# Altium schematic sheet Microcontroller_CC1350.SchDoc
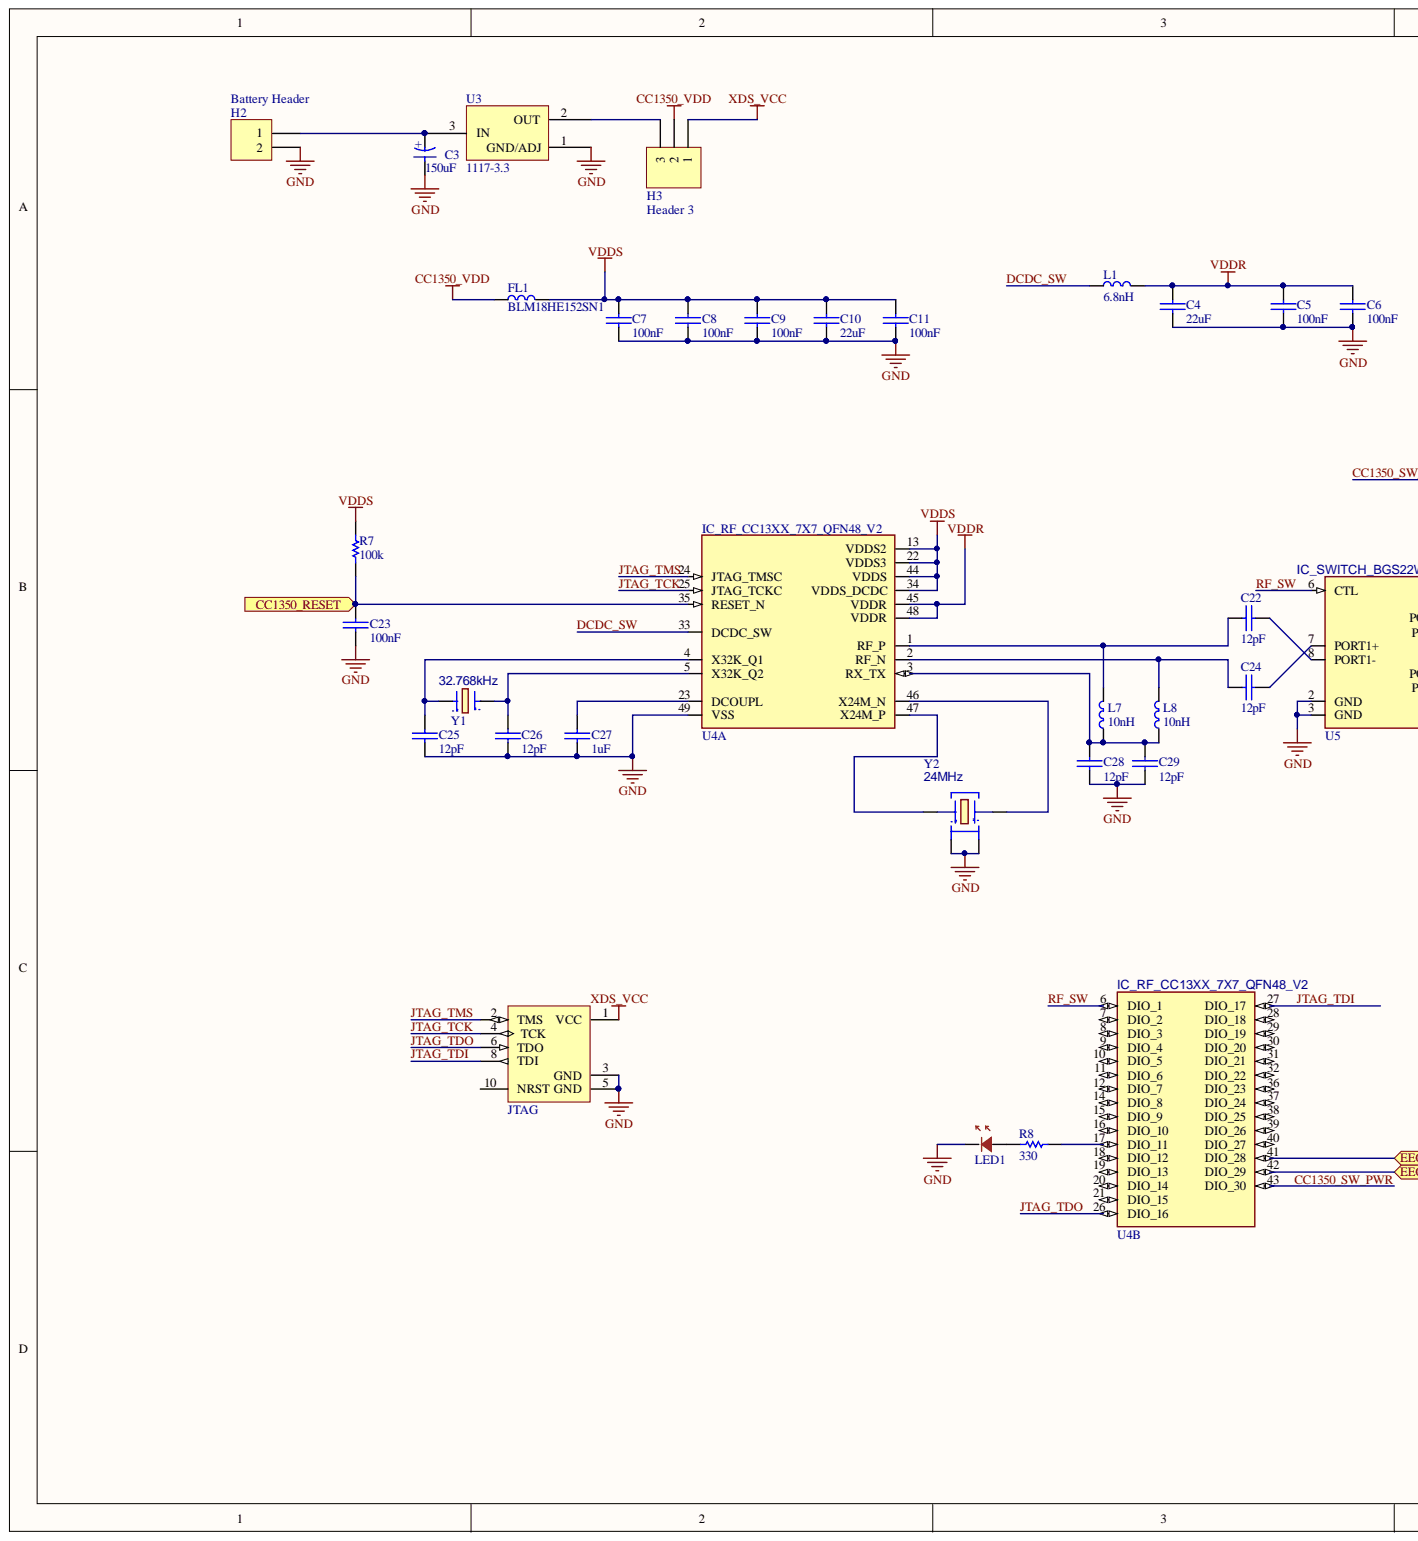
[diagram p0: Microcontroller_CC1350.SchDoc, left]
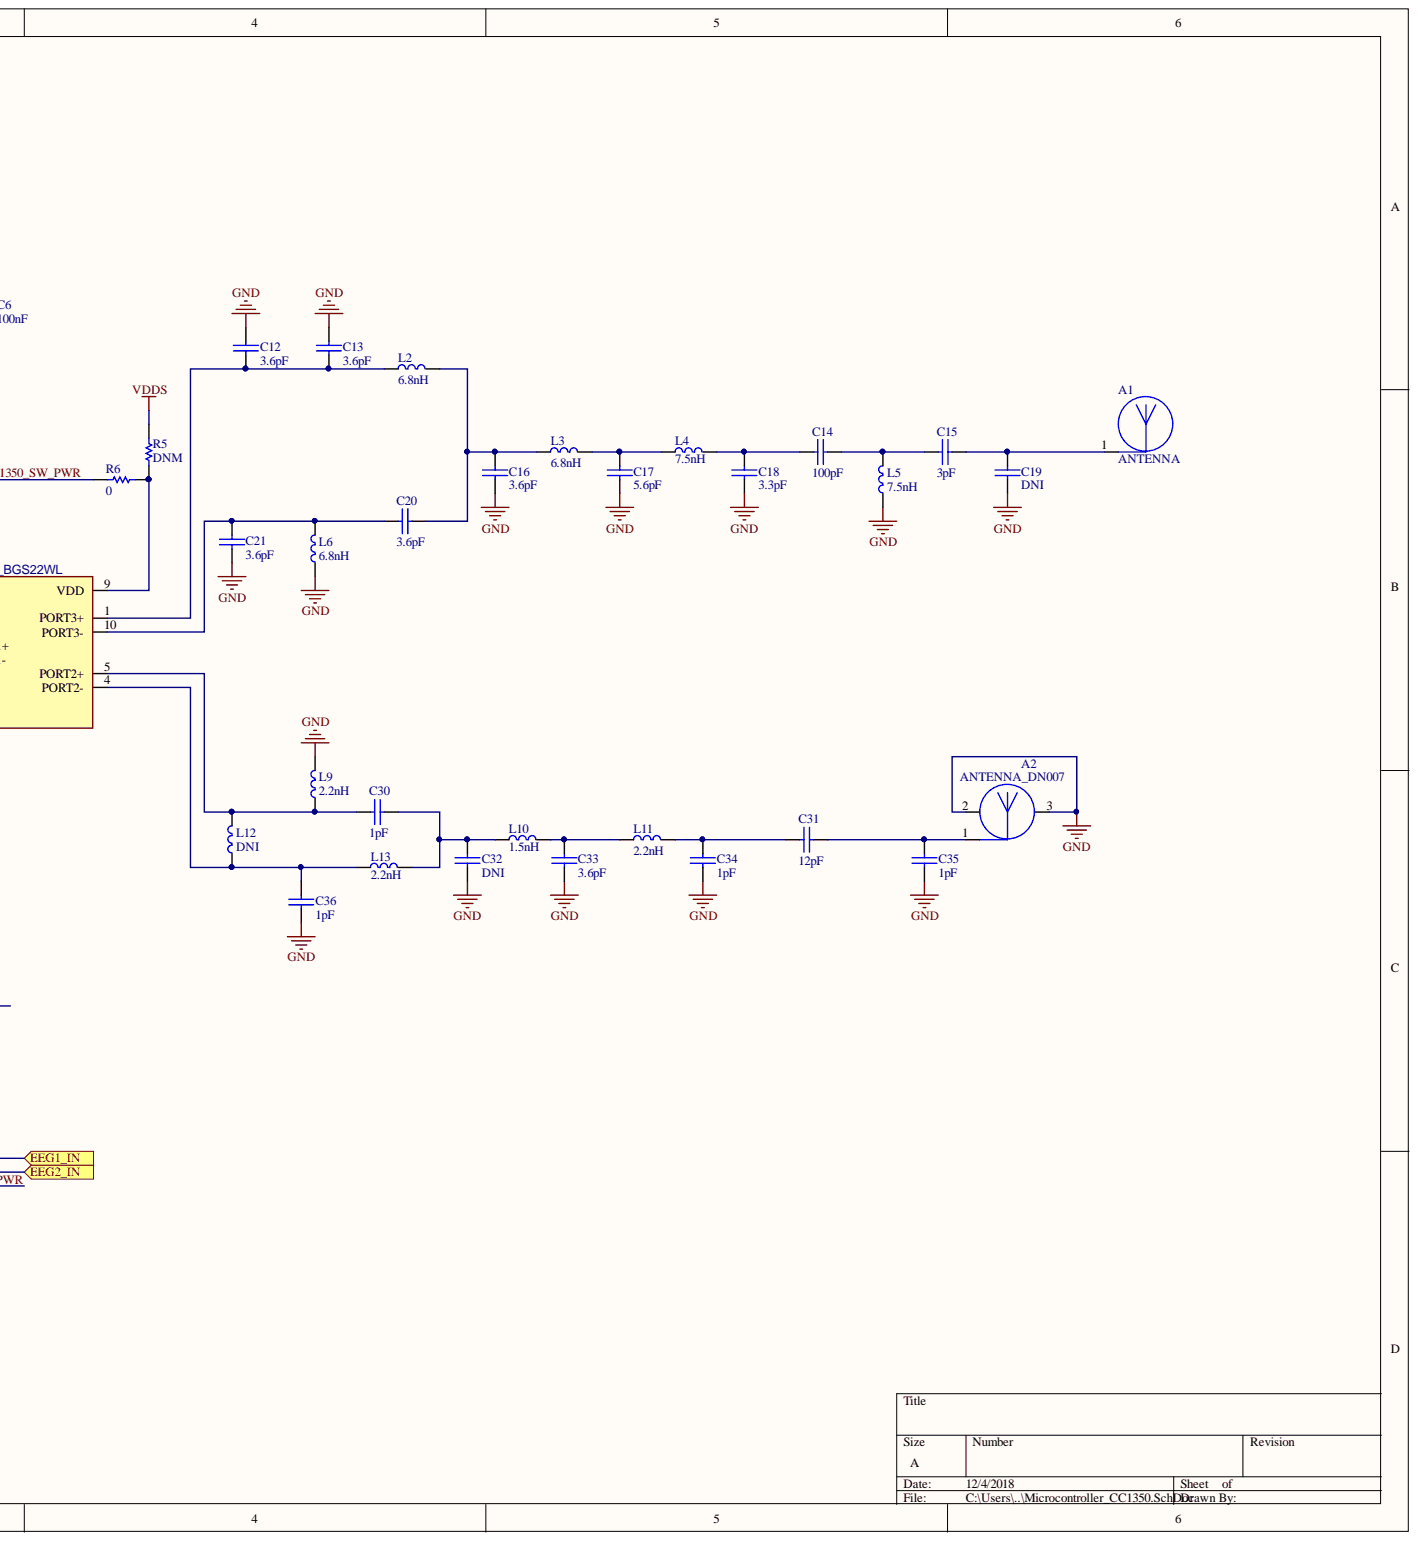
[diagram p1: Microcontroller_CC1350.SchDoc, right]

## Parts
A1  ANTENNA  [Sub-1GHz-Antenna]
A2  ANTENNA_DN007  [Inverted_F_Antenna]
C3  150uF  [2312 [IMP] Polar]
C4  22uF  [0603[IMP]]
C5  100nF  [0402[IMP]]
C6  100nF  [0402[IMP]]
C7  100nF  [0402[IMP]]
C8  100nF  [0402[IMP]]
C9  100nF  [0402[IMP]]
C10  22uF  [0603[IMP]]
C11  100nF  [0402[IMP]]
C12  3.6pF  [0402[IMP]]
C13  3.6pF  [0402[IMP]]
C14  100pF  [0402[IMP]]
C15  3pF  [0402[IMP]]
C16  3.6pF  [0402[IMP]]
C17  5.6pF  [0402[IMP]]
C18  3.3pF  [0402[IMP]]
C19  DNI  [0402[IMP]]
C20  3.6pF  [0402[IMP]]
C21  3.6pF  [0402[IMP]]
C22  12pF  [0402[IMP]]
C23  100nF  [0402[IMP]]
C24  12pF  [0402[IMP]]
C25  12pF  [0402[IMP]]
C26  12pF  [0402[IMP]]
C27  1uF  [0402[IMP]]
C28  12pF  [0402[IMP]]
C29  12pF  [0402[IMP]]
C30  1pF  [0402[IMP]]
C31  12pF  [0402[IMP]]
C32  DNI  [0402[IMP]]
C33  3.6pF  [0402[IMP]]
C34  1pF  [0402[IMP]]
C35  1pF  [0402[IMP]]
C36  1pF  [0402[IMP]]
FL1  BLM18HE152SN1  [0603[IMP]]
H2  Battery Header  [S2B-PH-SM4-TB]
H3  Header 3  [HDR1X3]
H4  JTAG  [HDR-2*5-0.05_Without_Through_Hole]
L1  6.8nH  [0402[IMP]]
L2  6.8nH  [0402[IMP]]
L3  6.8nH  [0402[IMP]]
L4  7.5nH  [0402[IMP]]
L5  7.5nH  [0402[IMP]]
L6  6.8nH  [0402[IMP]]
L7  10nH  [0402[IMP]]
L8  10nH  [0402[IMP]]
L9  2.2nH  [0402[IMP]]
L10  1.5nH  [0402[IMP]]
L11  2.2nH  [0402[IMP]]
L12  DNI  [0402[IMP]]
L13  2.2nH  [0402[IMP]]
LED1  LEDR  [0805[IMP]]  (value not drawn)
R5  DNM  [0402[IMP]]
R6  0  [0402[IMP]]
R7  100k  [0402[IMP]]
R8  330  [0402[IMP]]
U3  1117-3.3  [SOT-223]
U4  IC_RF_CC13XX_7X7_QFN48_V2  [RGZ(S-PVQFN-N48) - duplicate]  (parts A,B)
U5  IC_SWITCH_BGS22WL  [TSLP-10-1]
Y1  32.768kHz  [FC-135]
Y2  24MHz  [TSX-3225]

## Ports
CC1350_RESET, EEG1_IN, EEG2_IN

## Nets
CC1350_VDD: FL1.1 H3.2
GND: A2.2 A2.3 C3.1 C4.2 C5.2 C6.2 C7.2 C8.2 C9.2 C10.2 C11.2 C12.1 C13.1 C16.2 C17.2 C18.2 C19.1 C21.2 C23.2 C25.2 C26.2 C27.2 C28.2 C29.2 C32.1 C33.2 C34.1 C35.1 C36.2 H2.2 H4.3(GND) H4.5(GND) L5.1 L6.1 L9.2 LED1.2 U3.1 U4.49(VSS) U5.2(GND) U5.3(GND) Y2.2 Y2.4
VDDR: C4.1 C5.1 C6.1 L1.2 U4.45(VDDR) U4.48(VDDR)
VDDS: C7.1 C8.1 C9.1 C10.1 C11.1 FL1.2 R5.2 R7.2 U4.13(VDDS2) U4.22(VDDS3) U4.34(VDDS_DCDC) U4.44(VDDS)
XDS_VCC: H3.1 H4.1(VCC)
CC1350_RESET: C23.1 R7.1 U4.35(RESET_N) ; port CC1350_RESET
CC1350_SW_PWR: R6.2 U4.43(DIO_30)
DCDC_SW: L1.1 U4.33(DCDC_SW)
EEG1_IN: U4.41(DIO_28) ; port EEG1_IN
EEG2_IN: U4.42(DIO_29) ; port EEG2_IN
JTAG_TCK: H4.4(TCK) U4.25(JTAG_TCKC)
JTAG_TDI: H4.8(TDI) U4.27(DIO_17)
JTAG_TDO: H4.6(TDO) U4.26(DIO_16)
JTAG_TMS: H4.2(TMS) U4.24(JTAG_TMSC)
RF_SW: U4.6(DIO_1) U5.6(CTL)
NetA1_1: A1.1 C15.2 C19.2
NetA2_1: A2.1 C31.2 C35.2
NetC3_2: C3.2 H2.1 U3.3
NetC12_2: C12.2 C13.2 L2.1 U5.1(PORT3+)
NetC14_1: C14.1 C18.1 L4.2
NetC14_2: C14.2 C15.1 L5.2
NetC16_1: C16.1 C20.2 L2.2 L3.1
NetC17_1: C17.1 L3.2 L4.1
NetC20_1: C20.1 C21.1 L6.2 U5.10(PORT3-)
NetC22_1: C22.1 L7.2 U4.1(RF_P)
NetC22_2: C22.2 U5.8(PORT1-)
NetC24_1: C24.1 L8.2 U4.2(RF_N)
NetC24_2: C24.2 U5.7(PORT1+)
NetC25_1: C25.1 U4.4(X32K_Q1) Y1.1
NetC26_1: C26.1 U4.5(X32K_Q2) Y1.2
NetC27_1: C27.1 U4.23(DCOUPL)
NetC28_1: C28.1 C29.1 L7.1 L8.1 U4.3(RX_TX)
NetC30_1: C30.1 L9.1 L12.2 U5.5(PORT2+)
NetC30_2: C30.2 C32.2 L10.1 L13.2
NetC31_1: C31.1 C34.2 L11.2
NetC33_1: C33.1 L10.2 L11.1
NetC36_1: C36.1 L12.1 L13.1 U5.4(PORT2-)
NetH3_3: H3.3 U3.2
NetLED1_1: LED1.1 R8.1
NetR5_1: R5.1 R6.1 U5.9(VDD)
NetR8_2: R8.2 U4.17(DIO_11)
NetU4_46: U4.46(X24M_N) Y2.3
NetU4_47: U4.47(X24M_P) Y2.1

Not connected: H4 10(NRST); U4 7(DIO_2) 8(DIO_3) 9(DIO_4) 10(DIO_5) 11(DIO_6) 12(DIO_7) 14(DIO_8) 15(DIO_9) 16(DIO_10) 18(DIO_12) 19(DIO_13) 20(DIO_14) 21(DIO_15) 28(DIO_18) 29(DIO_19) 30(DIO_20) 31(DIO_21) 32(DIO_22) 36(DIO_23) 37(DIO_24) 38(DIO_25) 39(DIO_26) 40(DIO_27)

## Library data of the parts
A1 = ANTENNA: CLASS: DISCRETE; Designed by: Pawel Kwaskiewicz; Component Kind: Standard; Design date: 2010-02-22; Library Name: Biosense_Altium_Library.IntLib
A2 = ANTENNA_DN007: Designed by: Artur Bielinski; Component Kind: Standard; Design date: 2011-09-19; Library Name: Biosense_Altium_Library.IntLib
C3 = Cap_E: Capacitor; Component Kind: Standard; Supplier 1: Digi-Key; Supplier Part Number 1: 478-3481-1-ND; Value: 150uF; Library Name: Biosense_Altium_Library.IntLib
C4-C36 = CAPACITOR: CLASS: DISCRETE; CURRENT: CIMAX; DIST: FLAT; KNEE: CBMAX; MAX_TEMP: CTMAX; PSpiceTemplate: C^@REFDES %1 %2 ?TOLERANCE|C^@REFDES| @VALUE ?IC/IC=@IC/ TC=@TC1,@TC2 ?TOLERANCE|\n.model C^@REFDES CAP C=1 DEV=@TOLERANCE%|; SLOPE: CSMAX; TC1: 0; VOLTAGE: CMAX; Component Kind: Standard; Simulation: CAPACITOR; TC2: 0; Library Name: Biosense_Altium_Library.IntLib
  C4 C10: Supplier 1: Digi-Key; Supplier Part Number 1: 490-7611-1-ND; Value: 22uF
  C5-C9 C11 C23: Supplier 1: Digi-Key; Supplier Part Number 1: 490-6327-1-ND; Value: 100nF
  C12 C16 C20 C21 C33: Supplier 1: Digi-Key; Supplier Part Number 1: 490-5939-1-ND; Value: 3.6pF
  C13: Supplier 1: Digi-Key; Supplier 2: Digi-Key; Supplier Part Number 1: 399-14982-1-ND; Supplier Part Number 2: 490-5939-1-ND; Value: 3.6pF
  C14: Supplier 1: Digi-Key; Supplier Part Number 1: 490-7753-1-ND; Value: 100pF
  C15: Supplier 1: Digi-Key; Supplier Part Number 1: 712-1282-1-ND; Value: 3pF
  C17: Supplier 1: Digi-Key; Supplier Part Number 1: 490-6250-1-ND; Value: 5.6pF
  C18: Supplier 1: Digi-Key; Supplier Part Number 1: 490-5938-1-ND; Value: 3.3pF
  C19 C32: Value: DNI
  C22 C24-C26 C28 C29: Supplier 1: Digi-Key; Supplier Part Number 1: 399-1013-1-ND; Value: 12pF
  C27: Supplier 1: Digi-Key; Supplier Part Number 1: 1276-1448-1-ND; Value: 1uF
  C30 C34-C36: Supplier 1: Digi-Key; Supplier Part Number 1: 490-3199-1-ND; Value: 1pF
  C31: Supplier 1: Digi-Key; Supplier Part Number 1: 490-5924-1-ND; Value: 12pF
FL1 L1-L13 = INDUCTOR: Current: LMAX; DIELECTRIC: DSMAX; DIST: FLAT; PSpiceTemplate: L^@REFDES %1 %2 ?TOLERANCE|L^@REFDES| @VALUE ?IC/IC=@IC/ ?TOLERANCE|\n.model l^@REFDES IND L=1 DEV=@TOLERANCE%|; Component Kind: Standard; Simulation: INDUCTOR; Library Name: Biosense_Altium_Library.IntLib
  FL1: Supplier 1: Digi-Key; Supplier Part Number 1: 490-5216-1-ND; Value: BLM18HE152SN1
  L1-L3 L6: Supplier 1: Digi-Key; Supplier Part Number 1: 587-1543-1-ND; Value: 6.8nH
  L4 L5: Supplier 1: Digi-Key; Supplier Part Number 1: 490-3456-1-ND; Value: 7.5nH
  L7 L8: Supplier 1: Digi-Key; Supplier Part Number 1: 712-1407-1-ND; Value: 10nH
  L9 L11 L13: Supplier 1: Digi-Key; Supplier Part Number 1: 490-6794-1-ND; Value: 2.2nH
  L10: Supplier 1: Digi-Key; Supplier Part Number 1: 490-6783-1-ND; Value: 1.5nH
  L12: Value: DNI
H2 = Header 2: Component Kind: Standard; Supplier 1: Digi-Key; Supplier Part Number 1: 455-1749-1-ND; Library Name: Biosense_Altium_Library.IntLib
H4 = JTAG-ARM: USB JTAG Programmer; Component Kind: Standard; Supplier 1: Digi-Key; Supplier Part Number 1: 609-3695-1-ND; Library Name: Biosense_Altium_Library.IntLib
LED1 = LED_R: LED; Component Kind: Standard; Supplier 1: Digi-Key; Supplier Part Number 1: 475-1278-1-ND; Value: ?; Library Name: Biosense_Altium_Library.IntLib
R5-R8 = RESISTOR: Component Kind: Standard; Library Name: Biosense_Altium_Library.IntLib
  R5: Value: DNM
  R6: Supplier 1: Digi-Key; Supplier Part Number 1: 541-0.0YBCT-ND; Value: 0
  R7: Supplier 1: Digi-Key; Supplier Part Number 1: 541-100KYACT-ND; Value: 100k
  R8: Supplier 1: Digi-Key; Supplier Part Number 1: P330LCT-ND; Value: 330
U3 = 1117-3.3V: 3.3V Voltage Regulator; Component Kind: Standard; Supplier 1: Digi-Key; Supplier Part Number 1: LM1117IMPX-3.3/NOPBCT-ND; Library Name: Biosense_Altium_Library.IntLib
U4 = IC_RF_CC13XX_7X7_QFN48_V2: Designed_By: Artur Bielinski; SPLIT_INST: TRUE; SWAP_INFO: (S1+S2); Component Kind: Standard; Design_Date: 2014-09-04; Supplier 1: Digi-Key; Supplier Part Number 1: 296-44716-1-ND; Library Name: Biosense_Altium_Library.IntLib
U5 = IC_SWITCH_XMSSJE3G0PA-00: CLASS: IC; Designed_By: Artur Bielinski; Component Kind: Standard; Design_Date: 2015-06-03; Supplier 1: Digi-Key; Supplier Part Number 1: BGS22WL10E6327XTSA1CT-ND; Library Name: Biosense_Altium_Library.IntLib
Y1 = CRYSTAL: Component Kind: Standard; Supplier 1: Digi-Key; Supplier Part Number 1: SER4089CT-ND; Library Name: Biosense_Altium_Library.IntLib
Y2 = XTAL_4PIN_S: Component Kind: Standard; Supplier 1: Digi-Key; Supplier Part Number 1: SER3635CT-ND; Library Name: Biosense_Altium_Library.IntLib
H3 = Header 3: Component Kind: Standard; Library Name: Biosense_Altium_Library.IntLib
